# Altium schematic sheet LCD&FLASH.SchDoc(LCD&FLASH)
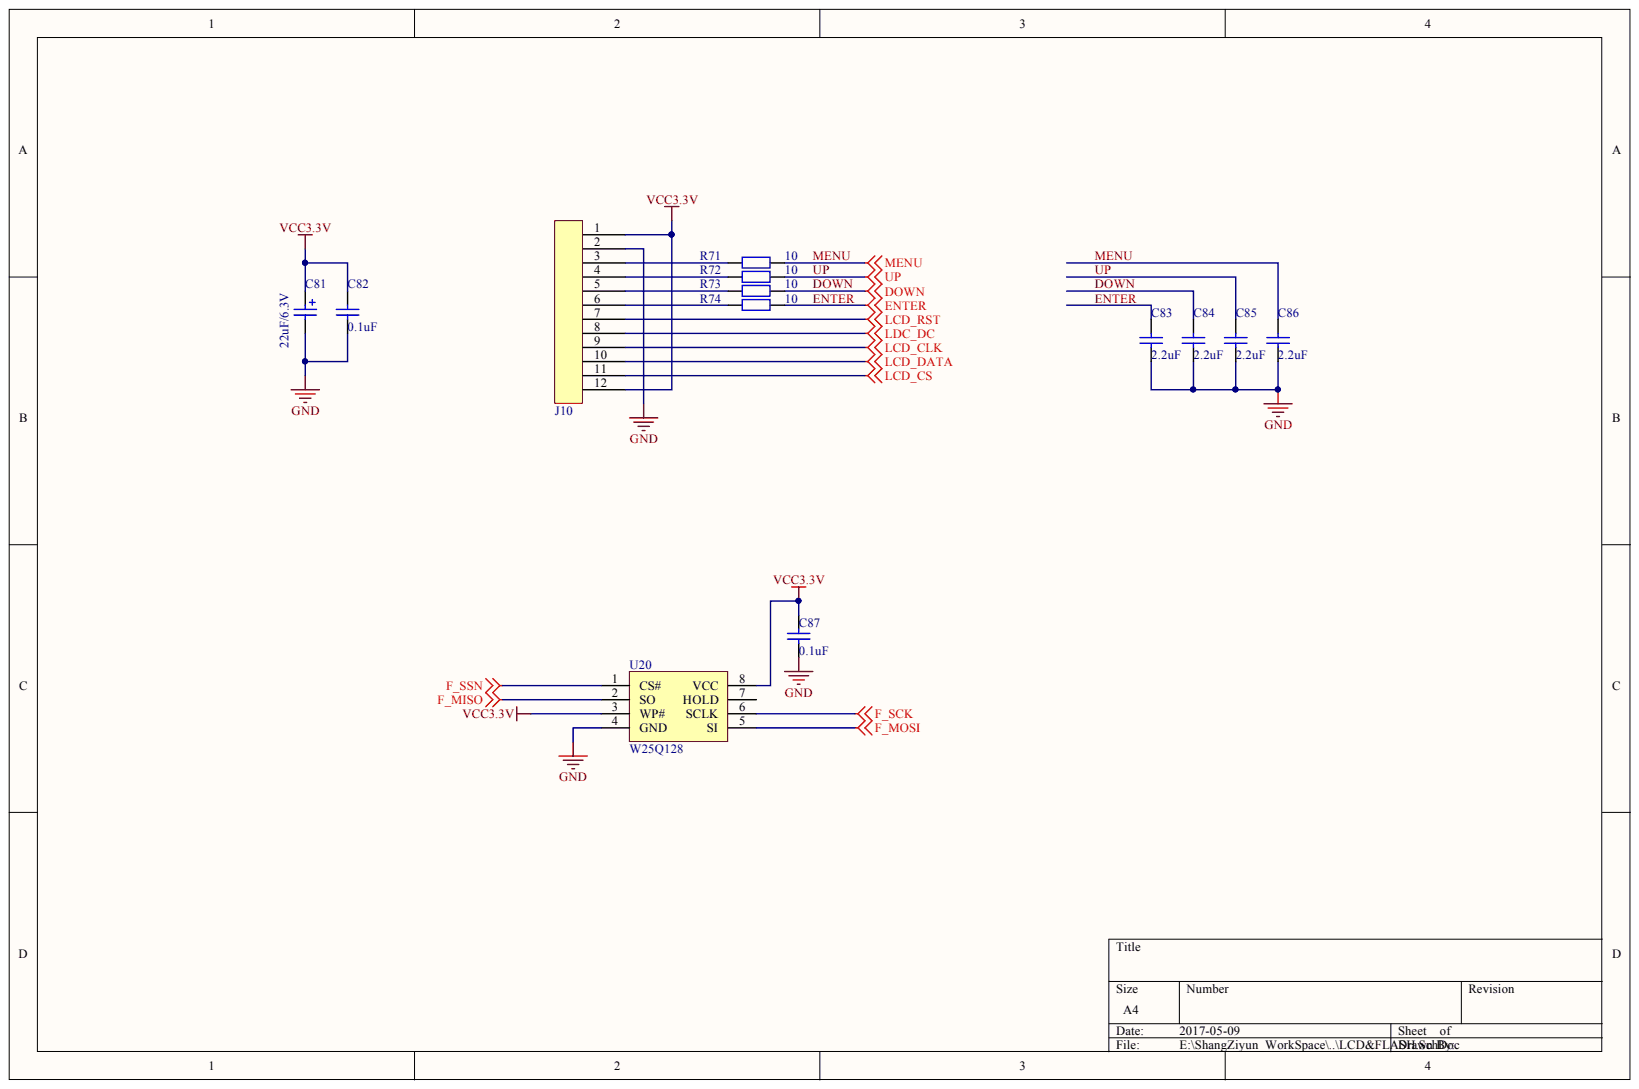
[diagram p0: LCD&FLASH.SchDoc]

## Parts
C81  22uF/6.3V  [EIA1206]
C82  value 0.1uF  [0603C]  (hidden comment: Capacitor)
C83  value 2.2uF  [0603C]  (hidden comment: Capacitor)
C84  value 2.2uF  [0603C]  (hidden comment: Capacitor)
C85  value 2.2uF  [0603C]  (hidden comment: Capacitor)
C86  value 2.2uF  [0603C]  (hidden comment: Capacitor)
C87  value 0.1uF  [0603C]  (hidden comment: Capacitor)
J10  con12  [XH2.54-12]  (value not drawn)
R71  value 10  [L0603]  (hidden comment: Resistor)
R72  value 10  [L0603]  (hidden comment: Resistor)
R73  value 10  [L0603]  (hidden comment: Resistor)
R74  value 10  [L0603]  (hidden comment: Resistor)
U20  W25Q128  [SOP8(8x6)]

## Nets
F_MISO: U20.2(SO)
F_MOSI: U20.5(SI)
F_SCK: U20.6(SCLK)
F_SSN: U20.1(CS#)
GND: C81.2 C82.2 C83.2 C84.2 C85.2 C86.2 C87.2 J10.2 U20.4(GND)
LCD_CLK: J10.9
LCD_CS: J10.11
LCD_DATA: J10.10
LCD_RST: J10.7
LDC_DC: J10.8
VCC3.3V: C81.1 C82.1 C87.1 J10.1 J10.12 U20.3(WP#) U20.8(VCC)
DOWN: C84.1 R73.1
ENTER: C83.1 R74.1
MENU: C86.1 R71.1
UP: C85.1 R72.1
NetJ10_3: J10.3 R71.2
NetJ10_4: J10.4 R72.2
NetJ10_5: J10.5 R73.2
NetJ10_6: J10.6 R74.2

Not connected: U20 7(HOLD)

## Library data of the parts
C81 = Cap pol: Value: 22uF/6.3V钽电容; Component Kind: Standard; Library Name: LNG.SCHLIB
C82-C87 = Cap: Component Kind: Standard; Description: Capacitor; LatestRevisionDate: 17-Jul-2002; LatestRevisionNote: Re-released for DXP Platform.; PackageDescription: Radial Cap, Thru-Hole; 2 Leads; 0.3 in Pin Spacing; PackageReference: RAD-0.3; Published: 8-Jun-2000; Signal Integrity: Cap; Simulation: CAP; Publisher: Altium Limited
  C82-C86: Library Name: Lib1.SCHLIB
  C87: Library Name: Miscellaneous Devices.IntLib
J10 = con12: Value: XH2.54x12; Component Kind: Standard; Library Name: 简配版生物罐.SCHLIB
R71-R74 = Res: Component Kind: Standard; Library Name: Lib1.SCHLIB
U20 = W25Q64: Component Kind: Standard; Value: W25Q128; Library Name: Bio.SchLib
